# Altium schematic sheet SDI.SchDoc(SDI)
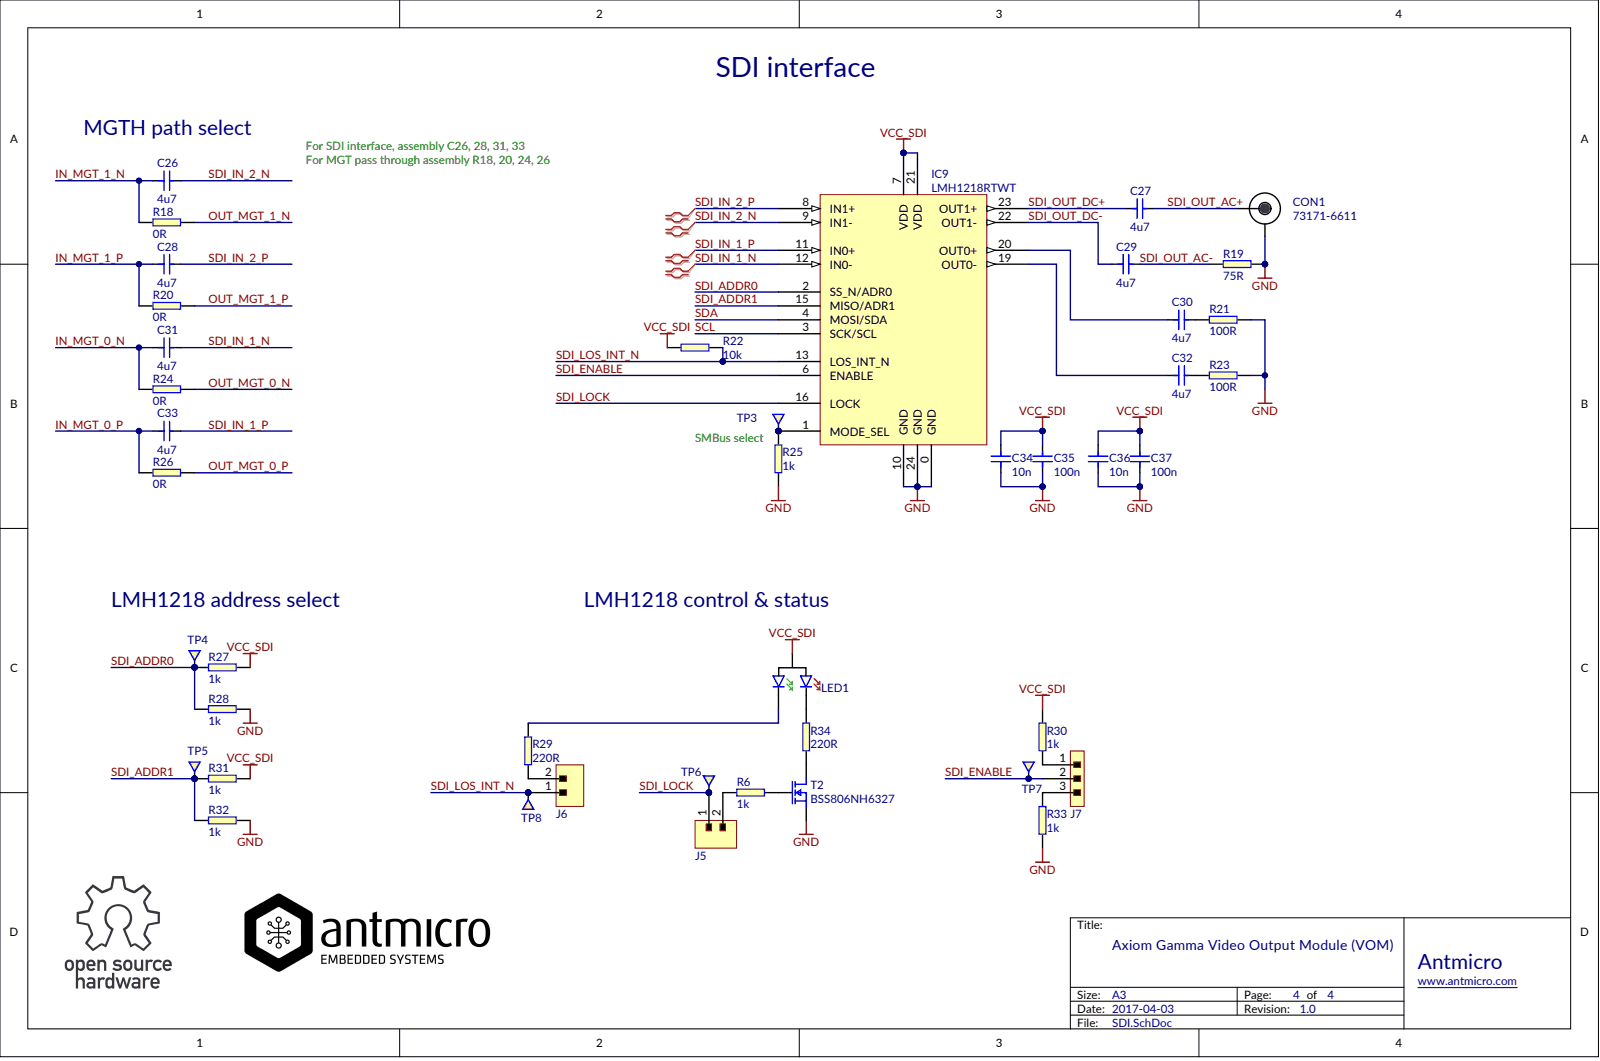
[diagram p0: SDI.SchDoc]

## Parts
C26  4u7
C27  4u7
C28  4u7
C29  4u7
C30  4u7
C31  4u7
C32  4u7
C33  4u7
C34  10n
C35  100n
C36  10n
C37  100n
CON1  73171-6611
IC9  LMH1218RTWT
J5  MH_1X02_2.54  (value not drawn)
J6  MH_1X02_2.54  (value not drawn)
J7  MH_1X03_2.54  (value not drawn)
LED1  LED-LiteON-LTST-S326KGJRKT  (value not drawn)
R6  1k
R18  0R
R19  75R
R20  0R
R21  100R
R22  10k
R23  100R
R24  0R
R25  1k
R26  0R
R27  1k
R28  1k
R29  220R
R30  1k
R31  1k
R32  1k
R33  1k
R34  220R
T2  BSS806NH6327
TP3  Testpad  (value not drawn)
TP4  Testpad  (value not drawn)
TP5  Testpad  (value not drawn)
TP6  Testpad  (value not drawn)
TP7  Testpad  (value not drawn)
TP8  Testpad  (value not drawn)

## Nets
GND: C34.1 C35.1 C36.1 C37.1 CON1.S IC9.0(GND) IC9.10(GND) IC9.24(GND) R19.1 R21.1 R23.1 R25.1 R28.2 R32.2 R33.2 T2.2
VCC_SDI: C34.2 C35.2 C36.2 C37.2 IC9.7(VDD) IC9.21(VDD) LED1.A R22.1 R27.2 R30.1 R31.2
IN_MGT_0_N: C31.1 R24.1
IN_MGT_0_P: C33.1 R26.1
IN_MGT_1_N: C26.1 R18.1
IN_MGT_1_P: C28.1 R20.1
OUT_MGT_0_N: R24.2
OUT_MGT_0_P: R26.2
OUT_MGT_1_N: R18.2
OUT_MGT_1_P: R20.2
SCL: IC9.3(SCK/SCL)
SDA: IC9.4(MOSI/SDA)
SDI_ADDR0: IC9.2(SS_N/ADR0) R27.1 R28.1 TP4.1
SDI_ADDR1: IC9.15(MISO/ADR1) R31.1 R32.1 TP5.1
SDI_ENABLE: IC9.6(ENABLE) J7.2 TP7.1
SDI_IN_1_N: C31.2 IC9.12(IN0-)
SDI_IN_1_P: C33.2 IC9.11(IN0+)
SDI_IN_2_N: C26.2 IC9.9(IN1-)
SDI_IN_2_P: C28.2 IC9.8(IN1+)
SDI_LOCK: IC9.16(LOCK) J5.1 TP6.1
SDI_LOS_INT_N: IC9.13(LOS_INT_N) J6.1 R22.2 TP8.1
SDI_OUT_AC+: C27.2 CON1.1
SDI_OUT_AC-: C29.2 R19.2
SDI_OUT_DC+: C27.1 IC9.23(OUT1+)
SDI_OUT_DC-: C29.1 IC9.22(OUT1-)
NetC30_1: C30.1 IC9.20(OUT0+)
NetC30_2: C30.2 R21.2
NetC32_1: C32.1 IC9.19(OUT0-)
NetC32_2: C32.2 R23.2
NetIC9_1: IC9.1(MODE_SEL) R25.2 TP3.1
NetJ5_2: J5.2 R6.1
NetJ6_2: J6.2 R29.2
NetJ7_1: J7.1 R30.2
NetJ7_3: J7.3 R33.1
NetLED1_C1: LED1.C1 R34.2
NetLED1_C2: LED1.C2 R29.1
NetR6_2: R6.2 T2.1
NetR34_1: R34.1 T2.3

## Library data of the parts
C26-C33 = (no symbol name): Part Number: C_4u7_0402; Manufacturer: MURATA; Part Symbol: GRM155R60G475ME; Value: 4u7
C34 C36 = (no symbol name): Part Number: C_10n_0402; Manufacturer: AVX; Part Symbol: 04025G103ZAT2A; Value: 10n
C35 C37 = (no symbol name): Part Number: C_100n_0402; Manufacturer: MULTICOMP; Part Symbol: MC0402X104M100CT; Value: 100n
CON1 = (no symbol name): Part Number: MOLEX_BNC_73171-6611; Manufacturer: MOLEX; Value: 73171-6611; Part Symbol: 73171-6611
IC9 = (no symbol name): Manufacturer: TEXAS INSTRUMENTS; Part Number: LMH1218RTWT; Value: LMH1218RTWT; Part Symbol: LMH1218RTWT
J5 J6 = (no symbol name): Manufacturer: MULTICOMP; Part Symbol: 2211S-02G; Part Number: MH_1X02_2.54; Value: MH_1X02_2.54
J7 = (no symbol name): Manufacturer: HARWIN; Part Symbol: M20-9990345.; Part Number: MH_1X03_2.54; Value: MH_1X03_2.54
LED1 = (no symbol name): Manufacturer: Lite-On; Part Number: LED-LiteON-LTST-S326KGJRKT; Value: LED-LiteON-LTST-S326KGJRKT; Part Symbol: LTST-S326KGJRKT
R6 R27 R28 R30-R33 = (no symbol name): Part Number: R_1k_0603; Manufacturer: BOURNS; Part Symbol: CR0603-JW-102ELF; Value: 1k
R18 R20 R24 R26 = (no symbol name): Part Number: R_0R_0402; Manufacturer: MULTICOMP; Part Symbol: MCMR04X000 PTL; Value: 0R
R19 = (no symbol name): Part Number: R_75R_0402; Manufacturer: YAGEO(PHYCOMP); Part Symbol: RC0402FR-0775RL; Value: 75R
R21 R23 = (no symbol name): Part Number: R_100R_0402; Manufacturer: BOURNS; Part Symbol: CR0402-FX-1000GLF; Value: 100R
R22 = (no symbol name): Part Number: R_10k_0402; Manufacturer: MULTICOMP; Part Symbol: MCMR04X1002FTL; Value: 10k
R25 = (no symbol name): Part Number: R_1k_0402; Manufacturer: MULTICOMP; Part Symbol: MCMR04X1001FTL; Value: 1k
R29 R34 = (no symbol name): Part Number: R_220R_0402; Manufacturer: TE CONNECTIVITY; Part Symbol: CRG0402J220R; Value: 220R
T2 = (no symbol name): Manufacturer: INFINEON; Part Symbol: BSS806NH6327XTSA1; Value: BSS806NH6327; Part Number: BSS806NH6327
TP3-TP8 = (no symbol name): Manufacturer: PCB ONLY; Part Number: Testpad; Part Symbol: PCB ONLY
